# Altium schematic sheet [3] - Gas Sensor and ADC.SchDoc
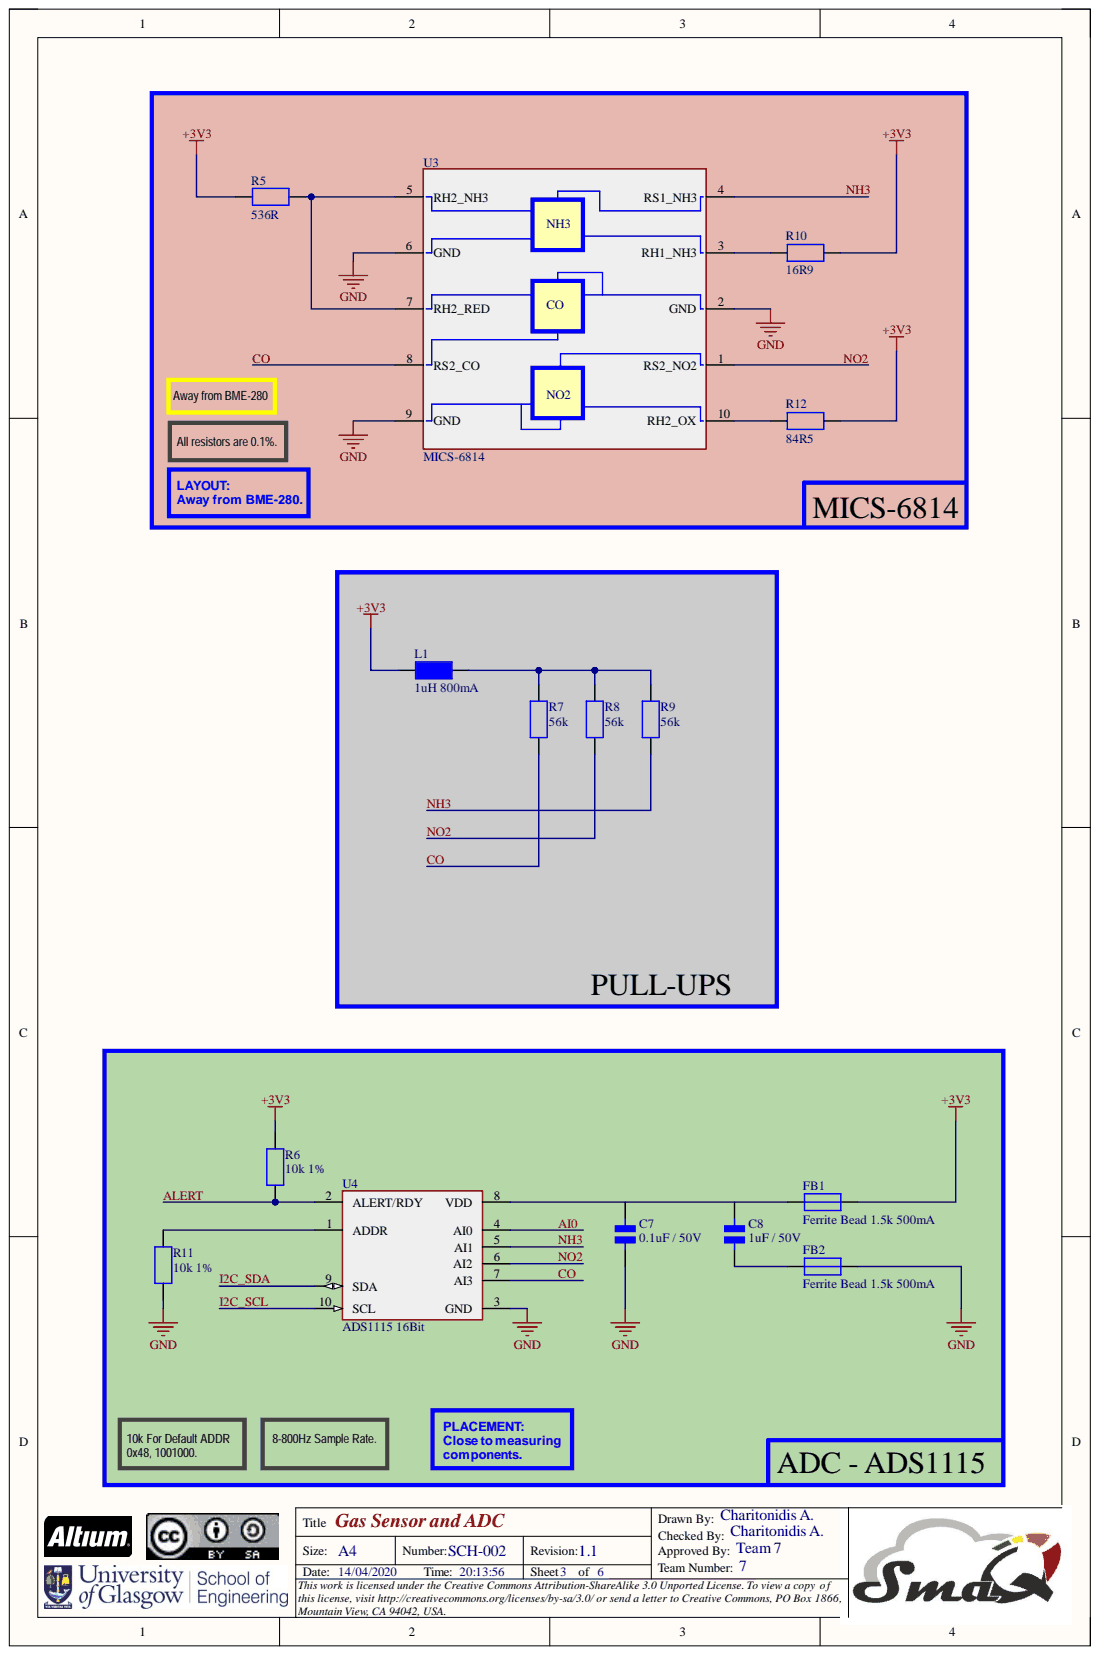
[diagram p0: [3] - Gas Sensor and ADC.SchDoc]

## Parts
C7  0.1uF / 50V  [C0805]  (no BOM)
C8  1uF / 50V  [C0805]  (no BOM)
FB1  Ferrite Bead 1.5k 500mA  Core Material: Ferrite  [C0805]  (no BOM)
FB2  Ferrite Bead 1.5k 500mA  Core Material: Ferrite  [C0805]  (no BOM)
L1  1uH 800mA  [C0805]  (no BOM)
R5  536R  [R0805 (2012M)]  (no BOM)
R6  10k 1%  [R0805 (2012M)]  (no BOM)
R7  56k  [R0805 (2012M)]  (no BOM)
R8  56k  [R0805 (2012M)]  (no BOM)
R9  56k  [R0805 (2012M)]  (no BOM)
R10  16R9  [R0805 (2012M)]  (no BOM)
R11  10k 1%  [R0805 (2012M)]  (no BOM)
R12  84R5  [R0805 (2012M)]  (no BOM)
U3  MICS-6814  [MICS-10]  (no BOM)
U4  ADS1115 16Bit  [VSSOP-10]  (no BOM)

## Nets
+3V3: FB1.2 L1.1 R5.1 R6.2 R10.2 R12.2
GND: C7.1 FB2.2 R11.1 U3.2(GND) U3.6(GND) U3.9(GND) U4.3(GND)
AI0: U4.4(AI0)
ALERT: R6.1 U4.2(ALERT/RDY)
CO: R7.1 U3.8(RS2_CO) U4.7(AI3)
I2C_SCL: U4.10(SCL)
I2C_SDA: U4.9(SDA)
NH3: R9.1 U3.4(RS1_NH3) U4.5(AI1)
NO2: R8.1 U3.1(RS2_NO2) U4.6(AI2)
NetC8_1: C8.1 FB2.1
NetFB1_1: FB1.1 U4.8(VDD)
NetL1_2: L1.2 R7.2 R8.2 R9.2
NetR5_2: R5.2 U3.5(RH2_NH3) U3.7(RH2_RED)
NetR10_1: R10.1 U3.3(RH1_NH3)
NetR11_2: R11.2 U4.1(ADDR)
NetR12_1: R12.1 U3.10(RH2_OX)

Not connected: C7 2; C8 2

## Library data of the parts
C7 = 08055C104JAT4A: CAP CER 0.1UF 50V X7R 0805; Manufacturer 1: Kyocera AVX; Capacitance: 100nF; Category 1: Ceramic Capacitors; Tolerance: 5%; Case Code (Imperial): 0805; Case Code (Metric): 2012; Case/Package: 0805; ComponentLink1Description: https://datasheet.ciiva.com/16326/cx7r-16326437.pdf?src-supplier=Future+Electronics; ComponentLink1URL: https://datasheet.ciiva.com/16326/cx7r-16326437.pdf?src-supplier=Future+Electronics; ComponentLink2Description: https://datasheet.ciiva.com/6277/cx7r-6277722.pdf?src-supplier=Digi-Key; ComponentLink2URL: https://datasheet.ciiva.com/6277/cx7r-6277722.pdf?src-supplier=Digi-Key; Depth: 1.25mm; Description 1: CAP CER 0.1UF 50V X7R 0805; Dielectric: X7R; Lead Free: Lead Free; Length: 2.01mm; Manufacturer Part Number 1: 08055C104JAT4A; Max Operating Temperature: 125°C; Min Operating Temperature: -55°C; Mount: Surface Mount; Number of Pins: 2; Package Quantity: 10000; Packaging: Tape and Reel; Radiation Hardening: No; RoHS: Yes; Stock 1: 70357; Supplier 1: Mouser; Supplier Part Number 1: 581-08055C104JAT4A; Termination: SMD/SMT; Thickness: 0.037inch; Voltage Rating: 50V; Voltage Rating (DC): 50V; Width: 0.049inch; Library Name: Parts_Lib_SMAQ.SchLib
C8 = 08055C105JAT2A: Manufacturer 1: Kyocera AVX; Category 1: Capacitors; Dielectric: X7R; Tolerance: 5%; Manufacturer Part Number 1: 08055C105JAT2A; RoHS: No; Stock 1: 5621; Supplier 1: Mouser; Supplier Part Number 1: 581-08055C105JAT2A; Voltage: 50V; Library Name: Parts_Lib_SMAQ.SchLib
FB1 FB2 = MMZ2012Y152B: Ferrite Beads Multi-Layer 1.5KOhm 25% 100MHz 500mA 400mOhm DCR 0805; Manufacturer 1: TDK; Category 1: Inductors; DC Resistance (DCR): 400mR; Impedance: 1.5kR; Shielding: Unshielded; Case Code (Imperial): 0805; Case Code (Metric): 2012; Case/Package: 0805; ComponentLink1Description: https://datasheet.ciiva.com/11800/0900766b8082f891-11800917.pdf?src-supplier=RSComponents; ComponentLink1URL: https://datasheet.ciiva.com/11800/0900766b8082f891-11800917.pdf?src-supplier=RSComponents; ComponentLink2Description: https://datasheet.ciiva.com/34302/1868995-34302918.pdf?src-supplier=Jameco; ComponentLink2URL: https://datasheet.ciiva.com/34302/1868995-34302918.pdf?src-supplier=Jameco; ComponentLink3Description: https://datasheet.ciiva.com/15160/getdatasheetpartid-77343-15160260.pdf?src-supplier=Verical; ComponentLink3URL: https://datasheet.ciiva.com/15160/getdatasheetpartid-77343-15160260.pdf?src-supplier=Verical; ComponentLink4Description: https://datasheet.ciiva.com/16001/e9412-mmz2012-16001336.pdf?src-supplier=Onlinecomponents.com; ComponentLink4URL: https://datasheet.ciiva.com/16001/e9412-mmz2012-16001336.pdf?src-supplier=Onlinecomponents.com; Current Rating: 500mA; DC Current: 500mA; Depth: 1.25mm; Description 1: Ferrite Beads Multi-Layer 1.5KOhm 25% 100MHz 500mA 400mOhm DCR 0805; Frequency: 100MHz; Height: 0.85mm; Lead Free: Lead Free; Length: 2mm; Manufacturer Part Number 1: MMZ2012Y152B; Material: Ferrite; Max DC Current: 500mA; Max Operating Temperature: 125°C; Military Standard: Not; Min Operating Temperature: -55°C; Number of Pins: 2; Package Quantity: 4000; Packaging: Tape and Reel; Pricing 1: 10=0.05740, 100=0.03680, 500=0.02670, 1000=0.02100, 2000=0.01900, 4000=0.01700, 20000=0.01600 (GBP); Radiation Hardening: No; REACH SVHC: No SVHC; RoHS: Yes; Supplier 1: Farnell; Supplier Part Number 1: 1301674; Termination: SMD/SMT; Test Frequency: 100MHz; Tolerance: 25%; Width: 1.25mm; Library Name: Parts_Lib_SMAQ.SchLib
L1 = KLZ2012MHR1R0HTD25: FIXED IND 1UH 800MA 130 MOHM SMD; Manufacturer 1: TDK; ComponentLink1Description: https://product.tdk.com/info/en/catalog/datasheets/inductor_automotive_decoupling_klz2012-hr_en.pdf?src-supplier=Digi-Key; ComponentLink1URL: https://product.tdk.com/info/en/catalog/datasheets/inductor_automotive_decoupling_klz2012-hr_en.pdf?src-supplier=Digi-Key; Description 1: FIXED IND 1UH 800MA 130 MOHM SMD; Manufacturer Part Number 1: KLZ2012MHR1R0HTD25; RoHS: No; Stock 1: 3633; Supplier 1: Mouser; Supplier Part Number 1: 810-KLZ2012MHR1R0HTD; Library Name: Parts_Lib_SMAQ.SchLib
R5 = ERA6AEB5360V: RES SMD 536 OHM 0.1% 1/8W 0805; Manufacturer 1: Panasonic; Category 1: Chip SMD Resistors; Max Power Dissipation: 125mW; Temperature Coefficient: 25ppm/°C; Voltage Rating: 100V; Case Code (Imperial): 0805; Case Code (Metric): 2012; Case/Package: 0805; ComponentLink1Description: https://datasheet.ciiva.com/11810/0900766b80eb1d8c-11810459.pdf?src-supplier=RSComponents; ComponentLink1URL: https://datasheet.ciiva.com/11810/0900766b80eb1d8c-11810459.pdf?src-supplier=RSComponents; ComponentLink2Description: https://datasheet.ciiva.com/41450/001181222-da-01-en-widerstand-era-0805-536r-0-1-5000st-41450380.pdf?src-supplier=Conrad; ComponentLink2URL: https://datasheet.ciiva.com/41450/001181222-da-01-en-widerstand-era-0805-536r-0-1-5000st-41450380.pdf?src-supplier=Conrad; ComponentLink3Description: https://datasheet.ciiva.com/9181/aoa0000ce26-9181246.pdf?src-supplier=Avnet; ComponentLink3URL: https://datasheet.ciiva.com/9181/aoa0000ce26-9181246.pdf?src-supplier=Avnet; Composition: Thin Film,Metal Film; Contact Plating: Tin; Depth: 1.25mm; Description 1: RES SMD 536 OHM 0.1% 1/8W 0805; Features: Automotive AEC-Q200; Height: 0.5mm; Lead Free: Lead Free; Length: 2mm; Manufacturer Part Number 1: ERA6AEB5360V; Max Operating Temperature: 155°C; Military Standard: Not; Min Operating Temperature: -55°C; Mount: Surface Mount; Number of Pins: 2; Number of Terminations: 2; Package Quantity: 1; Packaging: Tape and Reel; Power Rating: 125mW; Radiation Hardening: No; REACH SVHC: No SVHC; Resistance: 536R; RoHS: Yes; Stock 1: 8172; Supplier 1: Mouser; Supplier Part Number 1: 667-ERA-6AEB5360V; Termination: SMD/SMT; Tolerance: 0.1%; Voltage Rating (DC): 100V; Width: 1.25mm; Library Name: Parts_Lib_SMAQ.SchLib
R6 R11 = AT0805FRE0710KL: RES SMD 10K OHM 1% 1/8W 0805; Manufacturer 1: Yageo; Category 1: Chip SMD Resistors; Max Power Dissipation: 125mW; Resistance: 10kR; Temperature Coefficient: 50ppm/°C; Case Code (Imperial): 0805; Case Code (Metric): 2012; Case/Package: 0805; ComponentLink1Description: http://www.yageo.com/documents/recent/PYu-AT_51_RoHS_L_5.pdf?src-supplier=Digi-Key; ComponentLink1URL: http://www.yageo.com/documents/recent/PYu-AT_51_RoHS_L_5.pdf?src-supplier=Digi-Key; ComponentLink2Description: http://www.yageo.com/documents/recent/PYu-AT_51_RoHS_L-3.pdf?src-supplier=Digi-Key; ComponentLink2URL: http://www.yageo.com/documents/recent/PYu-AT_51_RoHS_L-3.pdf?src-supplier=Digi-Key; Composition: Thin Film; Description 1: RES SMD 10K OHM 1% 1/8W 0805; Features: Moisture Resistant,Automotive AEC-Q200,Anti-Sulfur; Height: 0.024inch; Length: 0.079inch; Manufacturer Part Number 1: AT0805FRE0710KL; Max Operating Temperature: 155°C; Min Operating Temperature: -55°C; Number of Terminations: 2; Packaging: Tape and Reel; RoHS: Yes; Stock 1: 12821; Supplier 1: Mouser; Supplier Part Number 1: 603-AT0805FRE0710KL; Tolerance: 1%; Width: 0.049inch; Library Name: Parts_Lib_SMAQ.SchLib
R7-R9 = ERA-6AEB563V: RES SMD 56K OHM 0.1% 1/8W 0805; Manufacturer 1: Panasonic; Category 1: Chip SMD Resistors; Max Power Dissipation: 125mW; Resistance: 56kR; Temperature Coefficient: 25ppm/°C; Voltage Rating: 100V; Case Code (Imperial): 0805; Case Code (Metric): 2012; Case/Package: 0805; ComponentLink1Description: https://datasheet.ciiva.com/11815/0900766b80beefcc-11815835.pdf?src-supplier=RSComponents; ComponentLink1URL: https://datasheet.ciiva.com/11815/0900766b80beefcc-11815835.pdf?src-supplier=RSComponents; ComponentLink2Description: https://datasheet.ciiva.com/26335/453572-da-01-en-widerstand-0805-era-26335719.pdf?src-supplier=Conrad; ComponentLink2URL: https://datasheet.ciiva.com/26335/453572-da-01-en-widerstand-0805-era-26335719.pdf?src-supplier=Conrad; ComponentLink3Description: https://datasheet.ciiva.com/6138/485442-6138597.pdf?src-supplier=Farnell; ComponentLink3URL: https://datasheet.ciiva.com/6138/485442-6138597.pdf?src-supplier=Farnell; ComponentLink4Description: https://datasheet.ciiva.com/48530/era-eng-tds-48530021.pdf?src-supplier=Distrelec; ComponentLink4URL: https://datasheet.ciiva.com/48530/era-eng-tds-48530021.pdf?src-supplier=Distrelec; ComponentLink5Description: https://datasheet.ciiva.com/6138/485442-6138602.pdf?src-supplier=Farnell; ComponentLink5URL: https://datasheet.ciiva.com/6138/485442-6138602.pdf?src-supplier=Farnell; ComponentLink6Description: https://datasheet.ciiva.com/7886/485442-7886650.pdf?src-supplier=Element14; ComponentLink6URL: https://datasheet.ciiva.com/7886/485442-7886650.pdf?src-supplier=Element14; ComponentLink7Description: https://datasheet.ciiva.com/7886/485442-7886651.pdf?src-supplier=Element14; ComponentLink7URL: https://datasheet.ciiva.com/7886/485442-7886651.pdf?src-supplier=Element14; ComponentLink8Description: https://datasheet.ciiva.com/30221/54aoa0000ce26-30221499.pdf?src-supplier=Onlinecomponents.com; ComponentLink8URL: https://datasheet.ciiva.com/30221/54aoa0000ce26-30221499.pdf?src-supplier=Onlinecomponents.com; ComponentLink9Description: https://datasheet.ciiva.com/6138/485442-6138604.pdf?src-supplier=Farnell; ComponentLink9URL: https://datasheet.ciiva.com/6138/485442-6138604.pdf?src-supplier=Farnell; ComponentLink10Description: https://datasheet.ciiva.com/7886/485442-7886652.pdf?src-supplier=Element14; ComponentLink10URL: https://datasheet.ciiva.com/7886/485442-7886652.pdf?src-supplier=Element14; ComponentLink11Description: https://datasheet.ciiva.com/17209/aoa0000ce26-17209230.pdf?src-supplier=Avnet+Europe; ComponentLink11URL: https://datasheet.ciiva.com/17209/aoa0000ce26-17209230.pdf?src-supplier=Avnet+Europe; Composition: Thin Film; Contact Plating: Tin; Depth: 1.25mm; Description 1: RES SMD 56K OHM 0.1% 1/8W 0805; Features: Automotive AEC-Q200; Height: 0.5mm; Lead Free: Lead Free; Length: 2mm; Manufacturer Part Number 1: ERA-6AEB563V; Max Operating Temperature: 155°C; Military Standard: Not; Min Operating Temperature: -55°C; Mount: Surface Mount; Number of Pins: 2; Number of Terminations: 2; Package Quantity: 1; Packaging: Tape and Reel; Power Rating: 125mW; Radiation Hardening: No; REACH SVHC: No SVHC; RoHS: Yes; Stock 1: 14319; Supplier 1: Mouser; Supplier Part Number 1: 667-ERA-6AEB563V; Termination: SMD/SMT; Tolerance: 0.1%; Voltage Rating (DC): 100V; Width: 1.25mm; Library Name: Parts_Lib_SMAQ.SchLib
R10 = ERJ-P06F17R4V: Res Thick Film 0805 16.9 Ohm 1% 1/4W 300ppm/C Molded SMD Punched Carrier T/R; Manufacturer 1: Panasonic; Category 1: Chip SMD Resistors; Description 1: Res Thick Film 0805 17.4 Ohm 1% 1/4W 300ppm/C Molded SMD Punched Carrier T/R; Power Rating: 500mW; Resistance: 17.4R; Temperature Coefficient: 300ppm/°C; Voltage Rating: 150V; Voltage Rating (DC): 400V; Case Code (Imperial): 0805; Case Code (Metric): 2012; Case/Package: 0805; ComponentLink1Description: https://datasheet.ciiva.com/24064/aoa0000ce4-64075-24064442.pdf?src-supplier=Mouser; ComponentLink1URL: https://datasheet.ciiva.com/24064/aoa0000ce4-64075-24064442.pdf?src-supplier=Mouser; ComponentLink2Description: https://datasheet.ciiva.com/22720/1801181-22720492.pdf?src-supplier=Element14; ComponentLink2URL: https://datasheet.ciiva.com/22720/1801181-22720492.pdf?src-supplier=Element14; Composition: Thick Film; Height: 0.6mm; Length: 2mm; Manufacturer Part Number 1: ERJ-P06F17R4V; Max Operating Temperature: 155°C; Min Operating Temperature: -55°C; Mount: Surface Mount; Package Quantity: 5000; Packaging: Tape and Reel; REACH SVHC: No SVHC; RoHS: Yes; Supplier 1: Mouser; Supplier Part Number 1: 667-ERJ-P06D17R4V; Termination: SMD/SMT; Tolerance: 1%; Width: 1.2mm; Library Name: Parts_Lib_SMAQ.SchLib
R12 = ERA-6AEB84R5V: RES SMD 84.5 OHM 0.1% 1/8W 0805; Manufacturer 1: Panasonic; Category 1: Chip SMD Resistors; Max Power Dissipation: 125mW; Resistance: 84.5R; Temperature Coefficient: 25ppm/°C; Voltage Rating: 100V; Case Code (Imperial): 0805; Case Code (Metric): 2012; Case/Package: 0805; ComponentLink1Description: https://datasheet.ciiva.com/11815/0900766b80eb1d8c-11815865.pdf?src-supplier=RSComponents; ComponentLink1URL: https://datasheet.ciiva.com/11815/0900766b80eb1d8c-11815865.pdf?src-supplier=RSComponents; ComponentLink2Description: https://datasheet.ciiva.com/41450/001181159-da-01-en-widerstand-era-0805-84r5-0-1-5000st-41450316.pdf?src-supplier=Conrad; ComponentLink2URL: https://datasheet.ciiva.com/41450/001181159-da-01-en-widerstand-era-0805-84r5-0-1-5000st-41450316.pdf?src-supplier=Conrad; ComponentLink3Description: https://datasheet.ciiva.com/6075/485442-6075305.pdf?src-supplier=Farnell; ComponentLink3URL: https://datasheet.ciiva.com/6075/485442-6075305.pdf?src-supplier=Farnell; ComponentLink4Description: https://datasheet.ciiva.com/9187/aoa0000ce26-9187305.pdf?src-supplier=Avnet; ComponentLink4URL: https://datasheet.ciiva.com/9187/aoa0000ce26-9187305.pdf?src-supplier=Avnet; ComponentLink5Description: https://datasheet.ciiva.com/6075/485442-6075306.pdf?src-supplier=Farnell; ComponentLink5URL: https://datasheet.ciiva.com/6075/485442-6075306.pdf?src-supplier=Farnell; ComponentLink6Description: https://datasheet.ciiva.com/6075/485442-6075307.pdf?src-supplier=Farnell; ComponentLink6URL: https://datasheet.ciiva.com/6075/485442-6075307.pdf?src-supplier=Farnell; Composition: Metal Film,Thin Film; Contact Plating: Tin; Depth: 1.25mm; Description 1: RES SMD 84.5 OHM 0.1% 1/8W 0805; Features: Automotive AEC-Q200; Height: 0.5mm; Lead Free: Lead Free; Length: 2mm; Manufacturer Part Number 1: ERA-6AEB84R5V; Max Operating Temperature: 155°C; Min Operating Temperature: -55°C; Mount: Surface Mount; Number of Pins: 2; Number of Terminations: 2; Package Quantity: 1; Packaging: Tape and Reel; Power Rating: 125mW; Radiation Hardening: No; RoHS: Yes; Stock 1: 6145; Supplier 1: Mouser; Supplier Part Number 1: 667-ERA-6AEB84R5V; Termination: SMD/SMT; Tolerance: 0.1%; Voltage Rating (DC): 100V; Width: 1.25mm; Library Name: Parts_Lib_SMAQ.SchLib
U3 = MICS-6814: SHUT HEIGHT GAGE(119.5MM); Manufacturer 1: SGX Sensortech; Description 1: SHUT HEIGHT GAGE(119.5MM); Manufacturer Part Number 1: MICS-6814; Pricing 1: 1=15.34000, 5=14.06000, 10=12.78000, 25=11.83000 (GBP); RoHS: No; Supplier 1: Farnell; Supplier Part Number 1: 2925869; Library Name: Parts_Lib_SMAQ.SchLib
U4 = ADS1115IDGSR: IC ADC 16BIT 860SPS LP 10MSOP; Manufacturer 1: Texas Instruments; Category 1: Analog to Digital Converters (ADCs); Conversion Rate: 0.86KS/s; Integral Nonlinearity (INL): 1LSB; Interface: I2C; Max Supply Voltage: 5.5V; Min Supply Voltage: 2V; Number of A/D Converters: 1; Number of Bits: 16; Number of Inputs: 4; Polarity: Bipolar; Power Dissipation: 900uW; Resolution: 16b; Sampling Rate: 860S/s; Supply Type: Single; Case/Package: VSSOP; ComponentLink1Description: https://datasheet.ciiva.com/4031/47725463s8438921n7713-4031028.pdf?src-supplier=Arrow; ComponentLink1URL: https://datasheet.ciiva.com/4031/47725463s8438921n7713-4031028.pdf?src-supplier=Arrow; ComponentLink2Description: https://datasheet.ciiva.com/25993/sbas444b-25993205.pdf?src-supplier=Avnet+Europe; ComponentLink2URL: https://datasheet.ciiva.com/25993/sbas444b-25993205.pdf?src-supplier=Avnet+Europe; ComponentLink3Description: https://datasheet.ciiva.com/1304/ads1113-1304266.pdf?src-supplier=Digi-Key; ComponentLink3URL: https://datasheet.ciiva.com/1304/ads1113-1304266.pdf?src-supplier=Digi-Key; Contact Plating: Gold; Description 1: IC ADC 16BIT 860SPS LP 10MSOP; Lead Free: Lead Free; Manufacturer Part Number 1: ADS1115IDGSR; Max Operating Temperature: 125°C; Max Supply Voltage (DC): 5.5V; Min Operating Temperature: -40°C; Min Supply Voltage (DC): 2V; Number of Converters: 1; Number of Pins: 10; Package Quantity: 2500; Packaging: Tape and Reel; Radiation Hardening: No; REACH SVHC: No SVHC; RoHS: Yes; Stock 1: 14706; Supplier 1: Mouser; Supplier Part Number 1: 595-ADS1115IDGSR; Library Name: Parts_Lib_SMAQ.SchLib
